# Altium schematic sheet RNBD451_Sheet.SchDoc(RNBD451_Sheet)
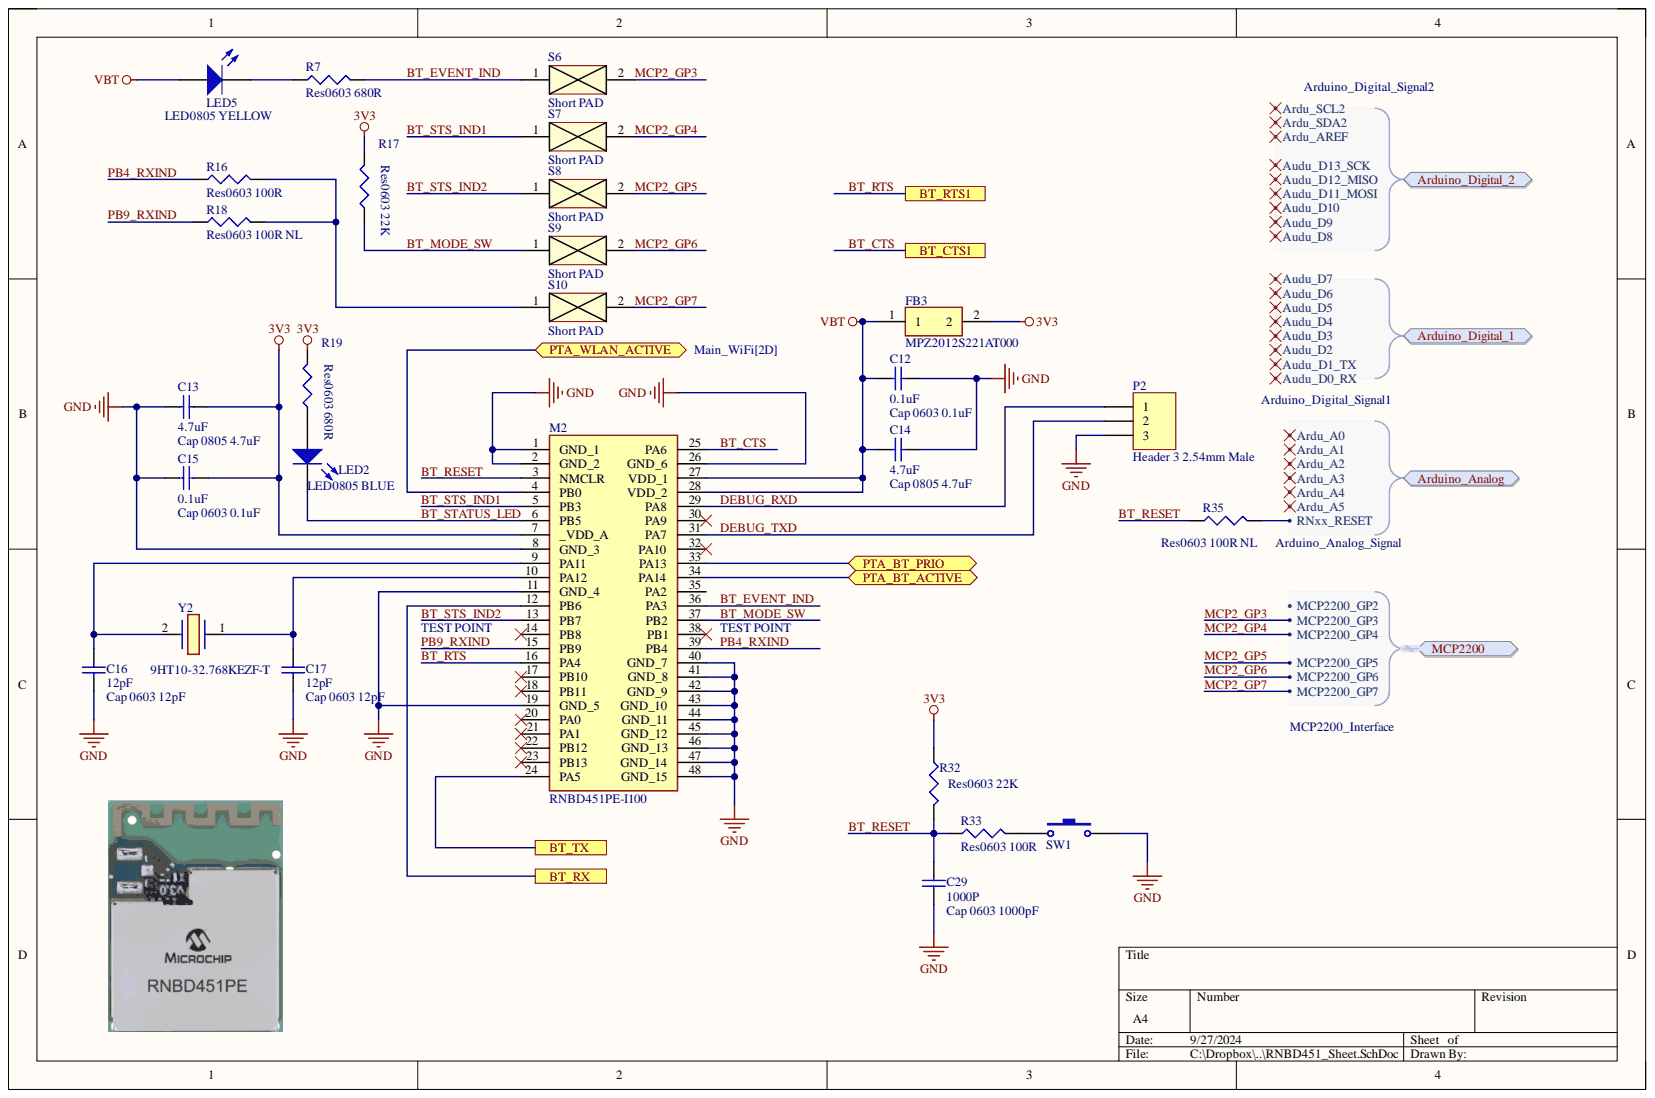
[diagram p0: RNBD451_Sheet.SchDoc]

## Parts
C12  Cap 0603 0.1uF  value 0.1uF  [0603C]
C13  Cap 0805 4.7uF  value 4.7uF  [C0805]
C14  Cap 0805 4.7uF  value 4.7uF  [C0805]
C15  Cap 0603 0.1uF  value 0.1uF  [0603C]
C16  Cap 0603 12pF  value 12pF  [0603C]
C17  Cap 0603 12pF  value 12pF  [0603C]
C29  Cap 0603 1000pF  value 1000P  [0603C]
FB3  MPZ2012S221AT000  [BEADC2012X105N]
LED2  LED0805 BLUE  [LED0805_B]
LED5  LED0805 YELLOW  [LED0805_Y]
M2  RNBD451PE-I100  [RNBD451PEI100]
P2  Header 3 2.54mm Male  [HDR1X3]
R7  Res0603 680R  [0603R]
R16  Res0603 100R  value 100R  [0603R]
R17  Res0603 22K  value 22K  [0603R]
R18  Res0603 100R NL  value 100R NL  [0603R]
R19  Res0603 680R  [0603R]
R32  Res0603 22K  value 22K  [0603R]
R33  Res0603 100R  value 100R  [0603R]
R35  Res0603 100R NL  value 100R NL  [0603R]
S6  Short PAD  [Short_PAD]
S7  Short PAD  [Short_PAD]
S8  Short PAD  [Short_PAD]
S9  Short PAD  [Short_PAD]
S10  Short PAD  [Short_PAD]
SW1  SW-PB  [Button_SMD]  (value not drawn)
Y2  9HT10-32.768KEZF-T  [9HT1032768KEZFT]

## Ports
Arduino_Analog, Arduino_Analog.Ardu_A0, Arduino_Analog.Ardu_A1, Arduino_Analog.Ardu_A2, Arduino_Analog.Ardu_A3, Arduino_Analog.Ardu_A4, Arduino_Analog.Ardu_A5, Arduino_Analog.RNxx_RESET, Arduino_Digital_1, Arduino_Digital_1.Audu_D0_RX, Arduino_Digital_1.Audu_D1_TX, Arduino_Digital_1.Audu_D2, Arduino_Digital_1.Audu_D3, Arduino_Digital_1.Audu_D4, Arduino_Digital_1.Audu_D5, Arduino_Digital_1.Audu_D6, Arduino_Digital_1.Audu_D7, Arduino_Digital_2, Arduino_Digital_2.Ardu_AREF, Arduino_Digital_2.Ardu_SCL2, Arduino_Digital_2.Ardu_SDA2, Arduino_Digital_2.Audu_D8, Arduino_Digital_2.Audu_D9, Arduino_Digital_2.Audu_D10, Arduino_Digital_2.Audu_D11_MOSI, Arduino_Digital_2.Audu_D12_MISO, Arduino_Digital_2.Audu_D13_SCK, BT_CTS1, BT_RTS1, BT_RX, BT_TX, MCP2200, MCP2200.MCP2200_GP2, MCP2200.MCP2200_GP3, MCP2200.MCP2200_GP4, MCP2200.MCP2200_GP5, MCP2200.MCP2200_GP6, MCP2200.MCP2200_GP7, PTA_BT_ACTIVE, PTA_BT_PRIO, PTA_WLAN_ACTIVE

## Nets
3V3: C13.2 C15.2 FB3.2 M2.7(_VDD_A) R17.2 R19.2 R32.1
GND: C12.2 C13.1 C14.2 C15.1 C16.1 C17.1 C29.1 M2.1(GND_1) M2.2(GND_2) M2.8(GND_3) M2.11(GND_4) M2.19(GND_5) M2.26(GND_6) M2.40(GND_7) M2.41(GND_8) M2.42(GND_9) M2.43(GND_10) M2.44(GND_11) M2.45(GND_12) M2.46(GND_13) M2.47(GND_14) M2.48(GND_15) P2.3 SW1.2
VBT: C12.1 C14.1 FB3.1 LED5.1 M2.27(VDD_1) M2.28(VDD_2)
Arduino_Analog: R35.2 ; port Arduino_Analog
BT_CTS: M2.25(PA6) ; port BT_CTS1
BT_EVENT_IND: M2.36(PA3) R7.2 S6.1
BT_MODE_SW: M2.37(PB2) R17.1 S9.1
BT_RESET: C29.2 M2.3(NMCLR) R32.2 R33.1 R35.1
BT_RTS: M2.16(PA4) ; port BT_RTS1
BT_RX: M2.12(PB6) ; port BT_RX
BT_STATUS_LED: LED2.2 M2.6(PB5)
BT_STS_IND1: M2.5(PB3) S7.1
BT_STS_IND2: M2.13(PB7) S8.1
BT_TX: M2.24(PA5) ; port BT_TX
DEBUG_RXD: M2.29(PA8) P2.1
DEBUG_TXD: M2.31(PA7) P2.2
MCP2_GP3: S6.2 ; port MCP2200
MCP2_GP4: S7.2 ; port MCP2200
MCP2_GP5: S8.2 ; port MCP2200
MCP2_GP6: S9.2 ; port MCP2200
MCP2_GP7: S10.2 ; port MCP2200
PB4_RXIND: M2.39(PB4) R16.1
PB9_RXIND: M2.15(PB9) R18.1
PTA_BT_ACTIVE: M2.34(PA14) ; port PTA_BT_ACTIVE
PTA_BT_PRIO: M2.33(PA13) ; port PTA_BT_PRIO
PTA_WLAN_ACTIVE: M2.4(PB0) ; port PTA_WLAN_ACTIVE
NetC16_2: C16.2 M2.9(PA11) Y2.2
NetC17_2: C17.2 M2.10(PA12) Y2.1
NetLED2_1: LED2.1 R19.1
NetLED5_2: LED5.2 R7.1
NetR16_2: R16.2 R18.2 S10.1
NetR33_2: R33.2 SW1.1
N00002: ; port MCP2200
N00004: ; port Arduino_Digital_1
N00006: ; port Arduino_Analog
N00008: ; port Arduino_Analog
N00011: ; port Arduino_Analog
N00014: ; port Arduino_Analog
N00017: ; port Arduino_Analog
N00019: ; port Arduino_Digital_1
N00021: ; port Arduino_Digital_1
N00023: ; port Arduino_Digital_1
N00025: ; port Arduino_Digital_1
N00027: ; port Arduino_Digital_1
N00029: ; port Arduino_Digital_1
N00031: ; port Arduino_Digital_1
N00033: ; port Arduino_Digital_2
N00035: ; port Arduino_Digital_2
N00037: ; port Arduino_Digital_2
N00040: ; port Arduino_Digital_2
N00043: ; port Arduino_Digital_2
N00046: ; port Arduino_Digital_2
N00049: ; port Arduino_Digital_2
N00052: ; port Arduino_Digital_2
N00054: ; port Arduino_Digital_2
N00056: ; port Arduino_Analog

Not connected: M2 14(PB8) 17(PB10) 18(PB11) 20(PA0) 21(PA1) 22(PB12) 23(PB13) 30(PA9) 32(PA10) 35(PA2) 38(PB1)

## Library data of the parts
C12 C15-C17 C29 = Cap 0603: Capacitor (Semiconductor SIM Model); Component Kind: Standard; LatestRevisionDate: 29-May-2009; LatestRevisionNote: IPC-7351 Footprint Added.; Library Name: MCHP_Parts_2016.SchLib; PackageDescription: Chip Capacitor; PackageReference: C0603; Published: 8-Jun-2000; Publisher: Altium Limited
C13 C14 = Cap 0805: Capacitor (Semiconductor SIM Model); Component Kind: Standard; LatestRevisionDate: 29-May-2009; LatestRevisionNote: IPC-7351 Footprint Added.; Library Name: MCHP_Parts_2016.SchLib; PackageDescription: Chip Capacitor; PackageReference: C1206; Published: 8-Jun-2000; Publisher: Altium Limited
FB3 = MPZ2012S221AT000: Ferrite Bead; Manufacturer_Name: TDK; Arrow Part Number: MPZ2012S221AT000; Arrow Price/Stock: https://www.arrow.com/en/products/mpz2012s221at000/tdk?region=nac; Component Kind: Standard; Datasheet Link: https://product.tdk.com/system/files/dam/doc/product/emc/emc/beads/catalog/beads_commercial_power_mpz2012_en.pdf; Height: 1.05mm; Library Name: SamacSys.SchLib; Manufacturer_Part_Number: MPZ2012S221AT000; Mouser Part Number: 810-MPZ2012S221AT000; Mouser Price/Stock: https://www.mouser.co.uk/ProductDetail/TDK/MPZ2012S221AT000?qs=pLY5GE0xrmJYuLs3YjLRbg%3D%3D
LED2 = LED0805 BLUE: Typical BLUE SiC LED; Component Kind: Standard; DatasheetVersion: 16-Apr-2002; LatestRevisionDate: 17-Dec-2003; LatestRevisionNote: PCB Footprint replaced.; Library Name: MCHP_Parts_2016.SchLib; PackageDescription: SM LED; 2 C-Bend Leads; Body 3.5 x 2.8 x 1.9 mm, inc leads (LxWxH typ); PackageReference: 3.5X2.8X1.9; PackageVersion: 16-Apr-2002; Published: 8-Jun-2000; Publisher: Altium Limited
LED5 = LED0805 YELLOW: Typical BLUE SiC LED; Component Kind: Standard; DatasheetVersion: 16-Apr-2002; LatestRevisionDate: 17-Dec-2003; LatestRevisionNote: PCB Footprint replaced.; Library Name: MCHP_Parts_2016.SchLib; PackageDescription: SM LED; 2 C-Bend Leads; Body 3.5 x 2.8 x 1.9 mm, inc leads (LxWxH typ); PackageReference: 3.5X2.8X1.9; PackageVersion: 16-Apr-2002; Published: 8-Jun-2000; Publisher: Altium Limited
M2 = RNBD451PE-I100: Antenna; Manufacturer_Name: Microchip; Arrow Part Number: RNBD451PE-I100; Arrow Price/Stock: https://www.arrow.com/en/products/rnbd451pe-i100/microchip-technology?region=nac; Component Kind: Standard; Datasheet Link: https://ww1.microchip.com/downloads/aemDocuments/documents/WSG/ProductDocuments/DataSheets/RNBD451-Data-Sheet-70005514.pdf; Height: 2.8mm; Library Name: SamacSys.SchLib; Manufacturer_Part_Number: RNBD451PE-I100; Mouser Part Number: 579-RNBD451PE-I100; Mouser Price/Stock: https://www.mouser.co.uk/ProductDetail/Microchip-Technology/RNBD451PE-I100?qs=amGC7iS6iy9gnQtKmLcyVQ%3D%3D
P2 = Header 3: Header, 3-Pin; Component Kind: Standard; LatestRevisionDate: 17-Jul-2002; LatestRevisionNote: Re-released for DXP Platform.; Library Name: MCHP_Parts_2016.SchLib; Publisher: Altium Limited
R7 R19 = Res0603: Resistor; Value: 680; Component Kind: Standard; LatestRevisionDate: 29-May-2009; LatestRevisionNote: IPC-7351 Footprint Added.; Library Name: MCHP_Parts_2016.SchLib; PackageDescription: Chip Resistor; PackageReference: J1-0603; Published: 8-Jun-2000; Publisher: Altium Limited
R16-R18 R32 R33 R35 = Res0603: Resistor; Component Kind: Standard; LatestRevisionDate: 29-May-2009; LatestRevisionNote: IPC-7351 Footprint Added.; Library Name: MCHP_Parts_2016.SchLib; PackageDescription: Chip Resistor; PackageReference: J1-0603; Published: 8-Jun-2000; Publisher: Altium Limited
  R32: Value: 22K
S6-S10 = Short_Pads: Component Kind: Standard; Library Name: MCHP_Parts_2016.SchLib
SW1 = SW-PB: Switch; Component Kind: Standard; LatestRevisionDate: 17-Jul-2002; LatestRevisionNote: Re-released for DXP Platform.; Library Name: MCHP_Parts_2016.SchLib; PackageDescription: Switch; 2 Leads; PackageReference: SPST-2; Published: 24-Mar-1999; Publisher: Altium Limited
Y2 = 9HT10-32.768KEZF-T: Crystal or Oscillator; Manufacturer_Name: TXC CORPORATION; Arrow Part Number: 9HT10-32.768KEZF-T; Arrow Price/Stock: https://www.arrow.com/en/products/9ht10-32.768kezf-t/txc-corporation?region=europe; Component Kind: Standard; Datasheet Link: https://componentsearchengine.com/Datasheets/2/9HT10-32.768KEZF-T.pdf; Height: 0.85mm; Library Name: SamacSys.SchLib; Manufacturer_Part_Number: 9HT10-32.768KEZF-T; Mouser Part Number: 717-9HT10-32.768KEZF; Mouser Price/Stock: https://www.mouser.co.uk/ProductDetail/TXC-Corporation/9HT10-32.768KEZF-T?qs=2ISWkumneuHGn5PDpP%2FWxQ%3D%3D
